# Altium schematic sheet microsd_card.SchDoc
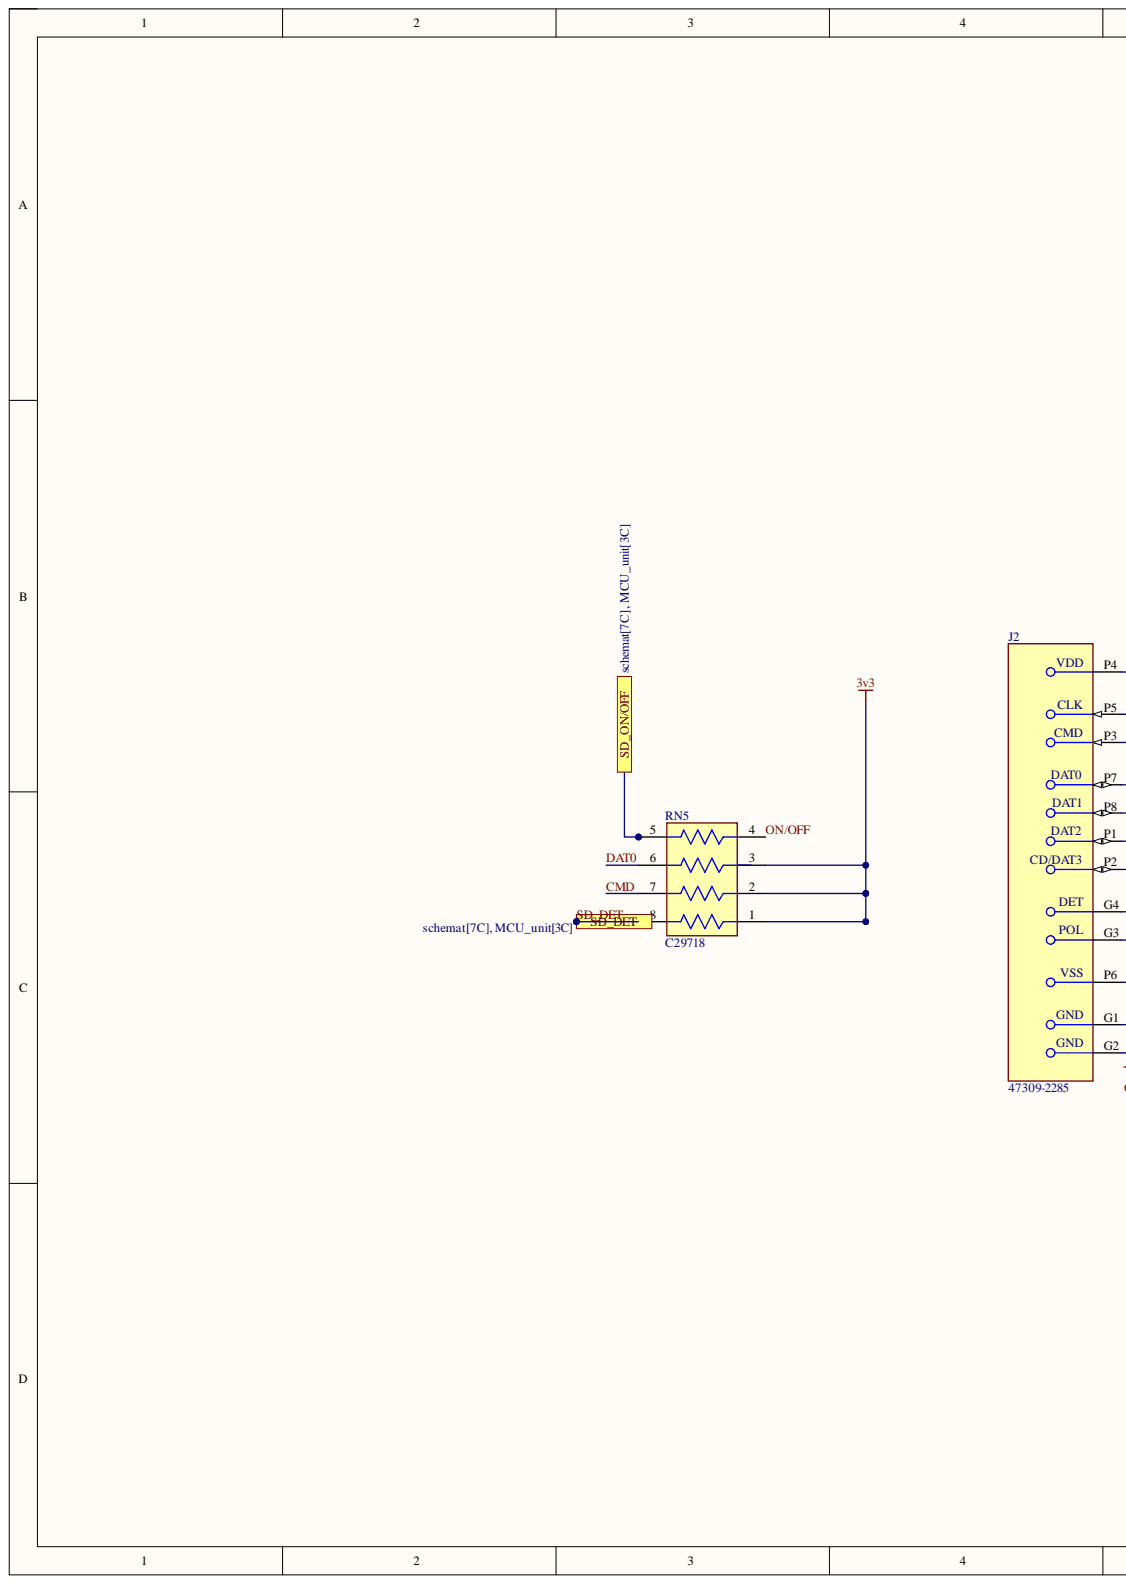
[diagram p0: microsd_card.SchDoc, left]
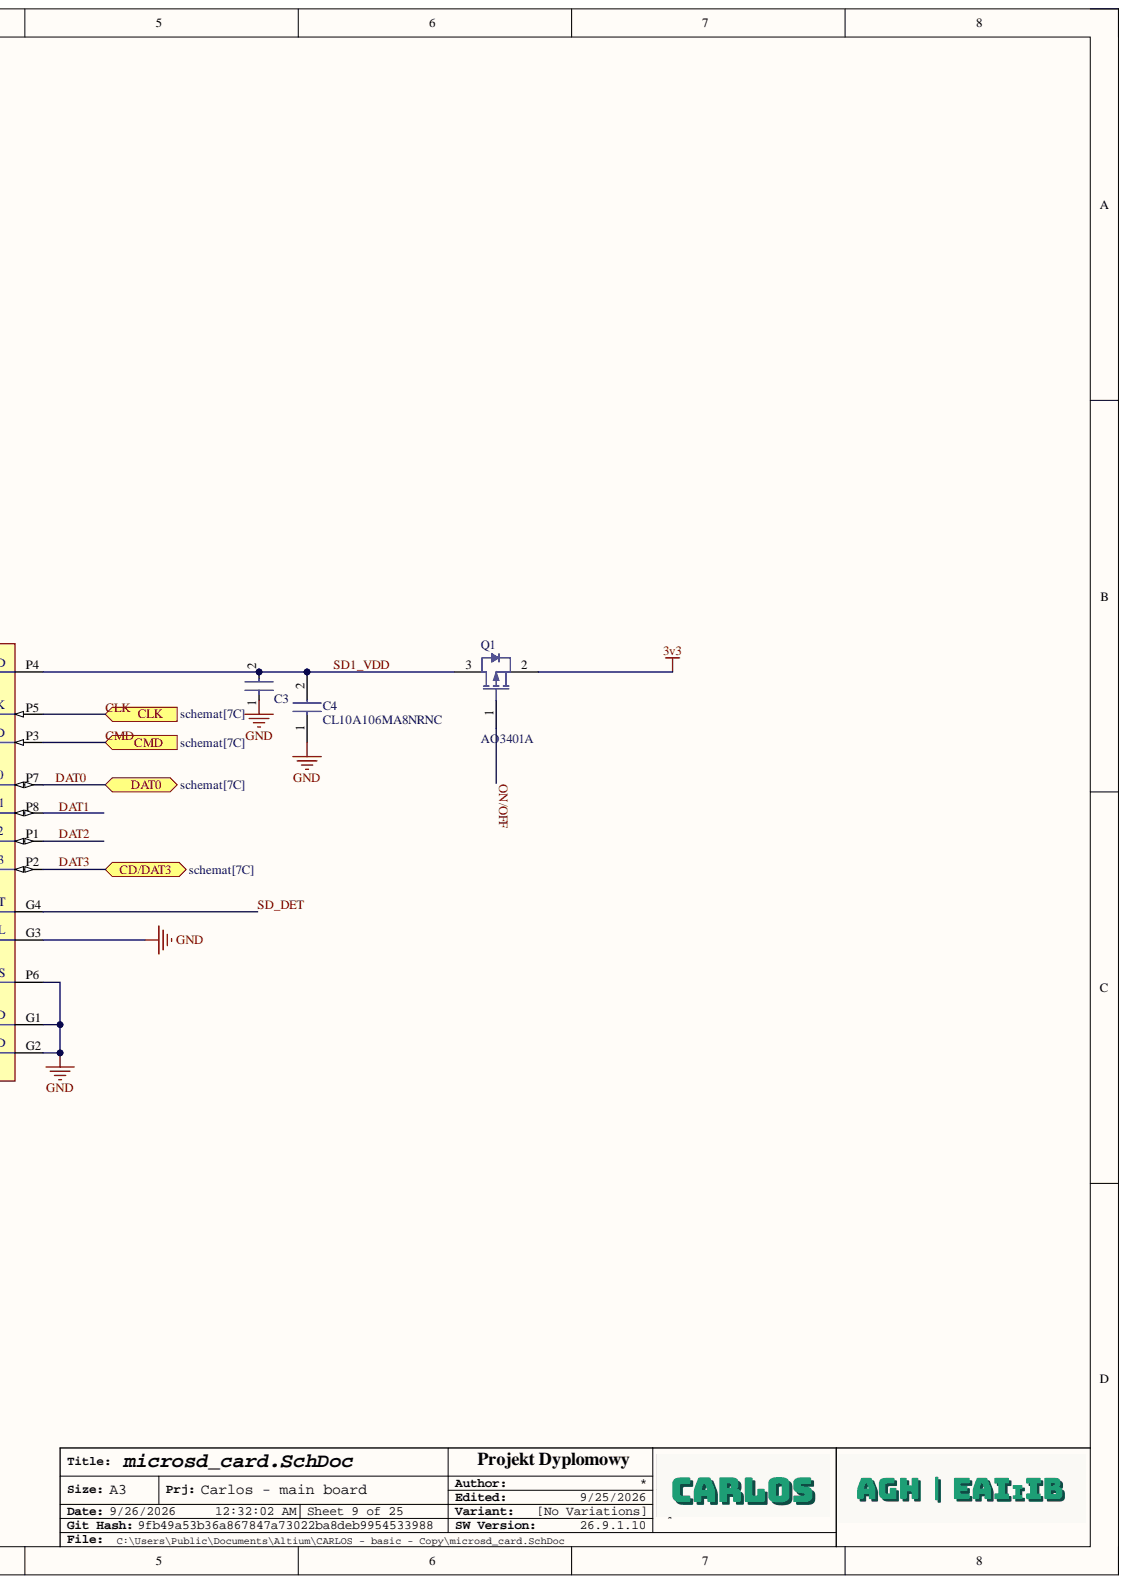
[diagram p1: microsd_card.SchDoc, right]

## Parts
C3  CL10B104KC8NNNC  [FP-CL10-IPC_A]  (value not drawn)
C4  CL10A106MA8NRNC  [CAPC1608X90N]
J2  47309-2285  [FP-47309-2285-MFG]
Q1  AO3401A  [AO-SOT-23-3L_V]
RN5  C29718  [FP-CAY16-J4-MFG]

## Ports
CD/DAT3, CLK, CMD, DAT0, SD_DET, SD_ON/OFF

## Nets
3v3: Q1.2 RN5.1 RN5.2 RN5.3
GND: C3.1 C4.1 J2.G1 J2.G2 J2.G3 J2.P6
CLK: J2.P5 ; port CLK
CMD: J2.P3 RN5.7 ; port CMD
DAT0: J2.P7 RN5.6 ; port DAT0
DAT1: J2.P8
DAT2: J2.P1
DAT3: J2.P2 ; port CD/DAT3
ON/OFF: Q1.1 RN5.4
SD1_VDD: C3.2 C4.2 J2.P4 Q1.3
SD_DET: J2.G4 RN5.8 ; port SD_DET
SD_ON/OFF: RN5.5 ; port SD_ON/OFF

## Library data of the parts
C3 = CMP-13271-004036-1: Cap Ceramic 100nF 100V X7R ±10% Pad SMD 0603 +125°C T/R; Capacitance: 100nF; Case Code (Imperial): 0603; Case Code (Metric): 1608; Case/Package: 0603; Component Kind: Standard; Length: 0.063inch; Library Name: Altium Content Vault; Max Operating Temperature: 125°C; Min Operating Temperature: -55°C; Mount: Surface Mount; Packaging: Cut Tape; Thickness: 0.035inch; Tolerance: 10%; Voltage Rating: 100V; Width: 0.031inch
C4 = CL10A106MA8NRNC: Capacitor; Manufacturer_Name: SAMSUNG; Arrow Part Number: CL10A106MA8NRNC; Arrow Price/Stock: https://www.arrow.com/en/products/cl10a106ma8nrnc/samsung-electro-mechanics.html?utm_currency=USD&region=nac; Component Kind: Standard; Datasheet Link: https://www.arrow.com/en/products/cl10a106ma8nrnc/samsung-electro-mechanics; Height: 0.9mm; Library Name: SamacSys.SchLib; Manufacturer_Part_Number: CL10A106MA8NRNC; Mouser Part Number: 187-CL10A106MA8NRNC; Mouser Price/Stock: https://www.mouser.co.uk/ProductDetail/Samsung-Electro-Mechanics/CL10A106MA8NRNC?qs=47mY1nHWefS2vFRvRJVTdQ%3D%3D
J2 = CMP-00257-38757042-1: 47309-2285 Molex 47309-2285 Memory Card Connectors Microsd Card Header W/Detect Pin H2.85mm,; Manufacturer Lifecycle Status: ACTIVE; Number of Circuits: 8; Plating: Gold; Component Kind: Standard; Contact Plating: Gold; Country of Origin: CN; Housing Material: Stainless Steel; Library Name: Altium Content Vault; Lifecycle Status: Production; NexarPartId: 38757042; RoHS: Compliant
Q1 = CMP-2000-05778-1: P-Channel MOSFET, 30 V, 4 A, -55 to 150 degC, 3-Pin SOT-23-3L, RoHS, Tape and Reel; Manufacturer: Alpha and Omega; Channel Mode: Enhancement; Continuous Drain Current: 4A; Drain Efficiency: NotRequired%; Drain-Source On-Res: 0.044ohm; Drain-Source On-Volt: 30V; Frequency (Max): NotRequiredMHz; Gate-Source Voltage (Max): ?12V; Noise Figure: NotRequireddB; Number of  Elements: 1; Output Power (Max): NotRequiredW; Polarity: P; Power Dissipation: 1.4W; Rad Hardened: No; Type: PowerMOSFET; Component Kind: Standard; Datasheet URL: http://www.aosmd.com/res/data_sheets/AO3401A.pdf; Datasheet Version: Rev. 3, 03/2011; Drain Current (Max): 4A; Library Name: Altium Content Vault; Manufacturer URL: http://www.aosmd.com/; Mounting: SurfaceMount; Mounting Technology: SurfaceMount; Operating Temp Range: -55Cto150C; Operating Temperature Classification: Military; Package Description: 3-Pin Small Outline Transistor, Body 2.95 x 1.6 mm, Pitch 0.95 mm; Package Reference: SOT-23-3L; Package Type: SOT-23; Package URL: http://www.aosmd.com/res/packaging_information/SOT-23-3L.pdf; Pin Count: 3; Power Gain: NotRequireddB
RN5 = CMP-07230-000006-1: zamiast tego 4D03WGJ0103T5E; Circuit Type: Isolated; Number of Circuits: 4; Number of Terminals/Pins: 8; Power Per Element: 62.5mW; Power Rating: 248mW; Resistance: 4.7kR; Resistance Tolerance: 5%; Temperature Coefficient: 200ppm/°C; Voltage Rating: 50V; Case Code (Imperial): 1206; Case Code (Metric): 3216; Case/Package: 1206; Component Kind: Standard; Contact Plating: Tin; Depth: 1.6mm; Height: 0.024inch; Length: 3.2mm; Library Name: Altium Content Vault; Max Operating Temperature: 125°C; Military Standard: Not; Min Operating Temperature: -55°C; Mount: Surface Mount; Number of Elements: 4; Number of Pins: 8; Number of Resistors: 4; Package Quantity: 1; Packaging: Tape and Reel; Radiation Hardening: No; REACH SVHC: No SVHC; Resistor Type: Thick Film; Series: CAY16; Technology: THICK FILM; Terminal Pitch: 0.8mm; Termination: Convex; Tolerance: 5%; Voltage Rating (DC): 50V; Width: 0.063inch
